# Altium schematic sheet Power.SchDoc(Power)
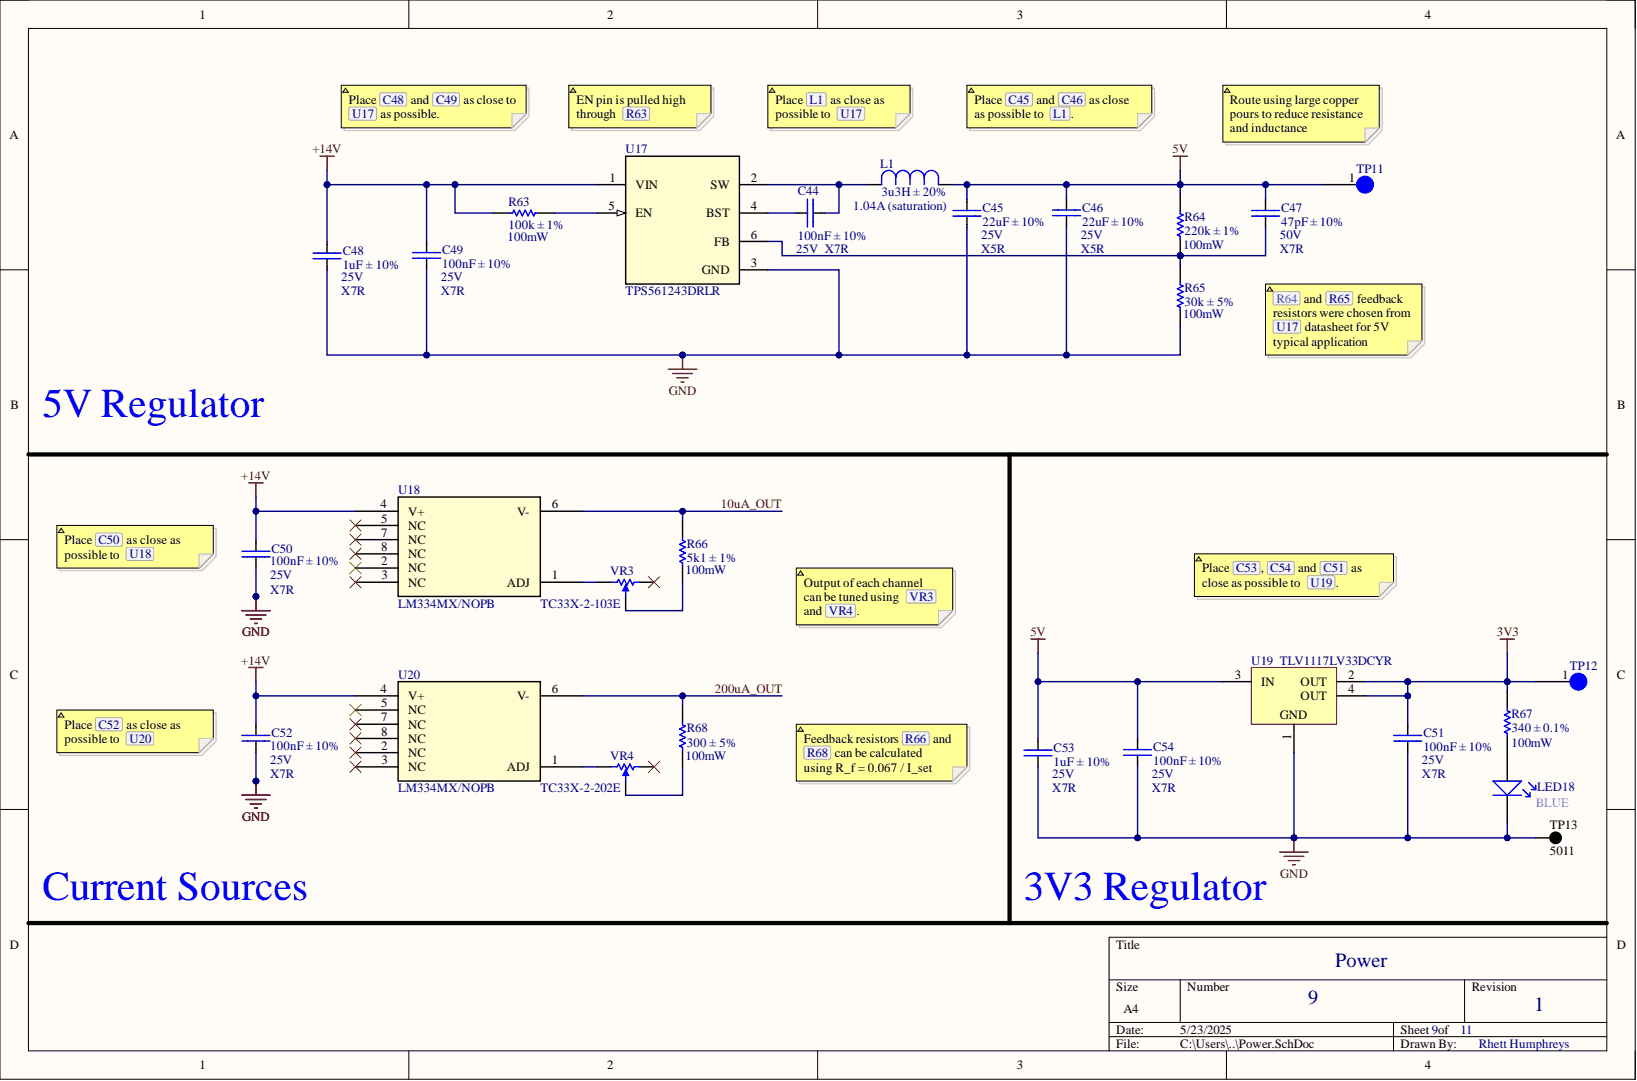
[diagram p0: Power.SchDoc]

## Parts
C44  100nF ± 10%  [CAP-0603]
C45  22uF ± 10%  [CAP-1206]
C46  22uF ± 10%  [CAP-1206]
C47  47pF ± 10%  [CAP-0603]
C48  1uF ± 10%  [CAP-0805]
C49  100nF ± 10%  [CAP-0603]
C50  100nF ± 10%  [CAP-0603]
C51  100nF ± 10%  [CAP-0603]
C52  100nF ± 10%  [CAP-0603]
C53  1uF ± 10%  [CAP-0805]
C54  100nF ± 10%  [CAP-0603]
L1  3u3H ± 20%  [IND_TAIYO_CBC3225_TAY]
LED18  (no value)  [0603 LED]
R63  100k ± 1%  [RES-0603]
R64  220k ± 1%  [RES-0603]
R65  30k ± 5%  [RES-0603]
R66  5k1 ± 1%  [RES-0603]
R67  340 ± 0.1%  [RES-0603]
R68  300 ± 5%  [RES-0603]
TP11  Test-Point 3mm  [TestPoint 3mm]  (value not drawn)
TP12  Test-Point 3mm  [TestPoint 3mm]  (value not drawn)
TP13  5011  [KSTN-5011_V]
U17  TPS561243DRLR  [DRL0006A-MFG]
U18  LM334MX/NOPB  [SO-8_STM]
U19  TLV1117LV33DCYR  [DCY0004A_N]
U20  LM334MX/NOPB  [SO-8_STM]
VR3  TC33X-2-103E  [BOUR-TC33X-2_V]
VR4  TC33X-2-202E  [BOUR-TC33X-2_V]

## Nets
3V3: C51.2 R67.1 TP12.1 U19.2(OUT) U19.4(OUT)
5V: C45.2 C46.2 C47.2 C53.2 C54.2 L1.1 R64.1 TP11.1 U19.3(IN)
+14V: C48.2 C49.2 C50.2 C52.2 R63.1 U17.1(VIN) U18.4(V+) U20.4(V+)
GND: C45.1 C46.1 C48.1 C49.1 C50.1 C51.1 C52.1 C53.1 C54.1 LED18.2 R65.2 TP13.1 U17.3(GND) U19.1
10uA_OUT: R66.1 U18.6(V-)
200uA_OUT: R68.1 U20.6(V-)
NetC44_1: C44.1 U17.4(BST)
NetC44_2: C44.2 L1.2 U17.2(SW)
NetC47_1: C47.1 R64.2 R65.1 U17.6(FB)
NetLED18_1: LED18.1 R67.2
NetR63_2: R63.2 U17.5(EN)
NetR66_2: R66.2 VR3.2
NetR68_2: R68.2 VR4.2
NetU18_1: U18.1(ADJ) VR3.1
NetU20_1: U20.1(ADJ) VR4.1

Not connected: U18 2(NC) 3(NC) 5(NC) 7(NC) 8(NC); U20 2(NC) 3(NC) 5(NC) 7(NC) 8(NC); VR3 3; VR4 3

## Library data of the parts
C44 C47 C49-C52 C54 = CAP-0603: Capacitor chip unpolarized. 0603; Component Kind: Standard; Library Name: Master.SchLib
C45 C46 = CAP-1206: Capacitor chip unpolarized. 0603; Component Kind: Standard; Library Name: Master.SchLib
C48 C53 = CAP-0805: Capacitor chip unpolarized. 0603; Component Kind: Standard; Library Name: Master.SchLib
L1 = CBC3225T3R3MR: No Description Available; Mfr_Name: Taiyo Yuden; Component Kind: Standard; Library Name: Master.SchLib
LED18 = 0603 LED: Component Kind: Standard; Library Name: Master.SchLib
R63-R68 = RES-0603: Resistor chip. 0603; Component Kind: Standard; Library Name: Master.SchLib
TP13 = CMP-1672-00003-4: Test Point, Black, Through Hole, RoHS, Bulk; Manufacturer: Keystone; Colour: Black; Component Kind: Standard; ComponentLink1Description: Manufacturer URL; ComponentLink1URL: http://www.keyelco.com/; ComponentLink2Description: Datasheet; ComponentLink2URL: http://www.keyelco.com/product-pdf.cfm?p=1320; Contact Material: Bronze; Contact Plating: Silver; DatasheetVersion: Rev. F, 12/2013; Height: 8.12 mm; Library Name: Altium Content Vault; Mount: Through Hole; Mounting Technology: Through Hole; Package Quantity: 100; PackageDescription: 1-Pin Through Hole Device, Dia 3.17 mm; PackageReference: 5011; Packaging: Bulk; Pins: 1; REACH SVHC: No SVHC; RoHSCompliant: true
U17 = TPS561243DRLR: No Description Available; Mfr_Name: Texas Instruments; Component Kind: Standard; Library Name: Master.SchLib; RefDes: RefDes; TYPE: TYPE
U18 U20 = LM234DT: No Description Available; Mfr_Name: STMicroelectronics; Component Kind: Standard; Library Name: Master.SchLib; RefDes: RefDes; Type: Type
U19 = CMP-0395-00503-2: Single Output LDO, 1 A, Fixed 3.3 V Output, 2 to 5.5 V Input, 4-pin SOT-223 (DCY), -40 to 125 degC, Green (RoHS & no Sb/Br); Manufacturer: Texas Instruments; Accuracy(%): 2; Fixed Output Options(V): 3.3; Iout(Max)(A): 1; Iq(Typ)(mA): 0.05; SubFamily: Single Channel LDO; Vdo(Typ)(mV): 1200; Vin(Max)(V): 5.5; Code_JEDEC: TO-261; Component Kind: Standard; ComponentLink1Description: Manufacturer URL; ComponentLink1URL: http://www.ti.com/; ComponentLink2Description: Datasheet; ComponentLink2URL: http://www.ti.com/general/docs/lit/getliterature.tsp?genericPartNumber=TLV1117LV33&fileType=pdf; ComponentLink3Description: Package Specification; ComponentLink3URL: http://www.ti.com/litv/pdf/mpds094a; DatasheetVersion: SBVS160A; Eco-Plan: Green (RoHS & no Sb/Br); Library Name: Altium Content Vault; Mounting Technology: Surface Mount; PackageDescription: 4-Pin Plastic Small Outline, Body 3.5 x 6.5 mm, Pitch 2.3 mm; PackageReference: DCY0004A; PackageVersion: 4202506/B; PartNumber: TLV1117LV33DCYR; Vin(Min)(V): 2; Vout Adj(Max)(V): 3.3; Vout Adj(Min)(V): 3.3
VR3 VR4 = CMP-2100-03695-1: Trimming Potentiometer, 2000 Ohm, -40 to 100 degC, 3-Pin SMD, RoHS, Tape and Reel; Manufacturer: Bourns; Max Power Dissipation: 100mW; Number of Turns: 1; Resistance: 10KOhm; Temperature Coefficient: 250ppm/degreesC; Tolerance: 25%; Voltage Rating (DC): 50V; Case/Package: SMD/SMT; Colour: Silver; Component Kind: Standard; Composition: Potentiometer; Contact Plating: Silver; Datasheet URL: http://www.bourns.com/docs/Product-Datasheets/TC33.pdf; Datasheet Version: 42583.0; Depth: 3.8mm; Height: 0.047inch; Lead Free: LeadFree; Length: 3mm; Library Name: Altium Content Vault; Manufacturer URL: http://www.bourns.com/; Max Operating Temperature: 100degC; Military Standard: Not; Min Operating Temperature: -40degC; Mount: SurfaceMount; Package Description: 3-Pin Surface Mount Device, Body 3.8 x 3.6 mm; Package Quantity: 2500; Package Reference: TC33X-2; Packaging: TapeandReel; Power Rating: 100mW; Radiation Hardening: No; REACH SVHC: NoSVHC; RoHSCompliant: true; Taper: Linear; Termination: FootPrint; Weight: 29.4mg; Width: 0.118inch
TP11 TP12 = Test-Point 3mm: Component Kind: Standard; Library Name: Master.SchLib
